# Altium schematic sheet CN032-1 Industry EtherCAT Servo Controller_LED&KEY.SchDoc
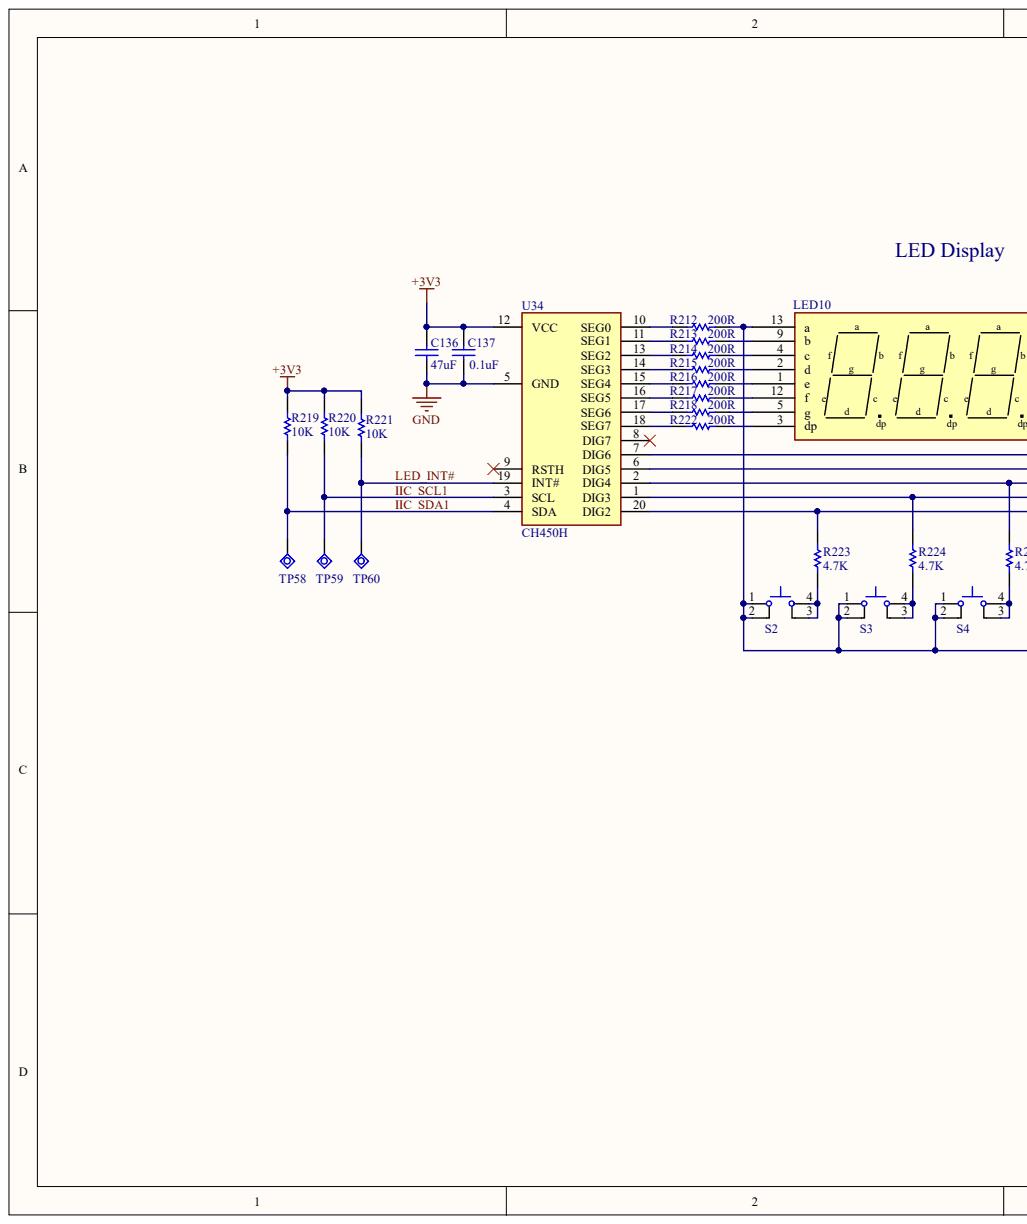
[diagram p0: CN032-1 Industry EtherCAT Servo Controller_LED&KEY.SchDoc, left]
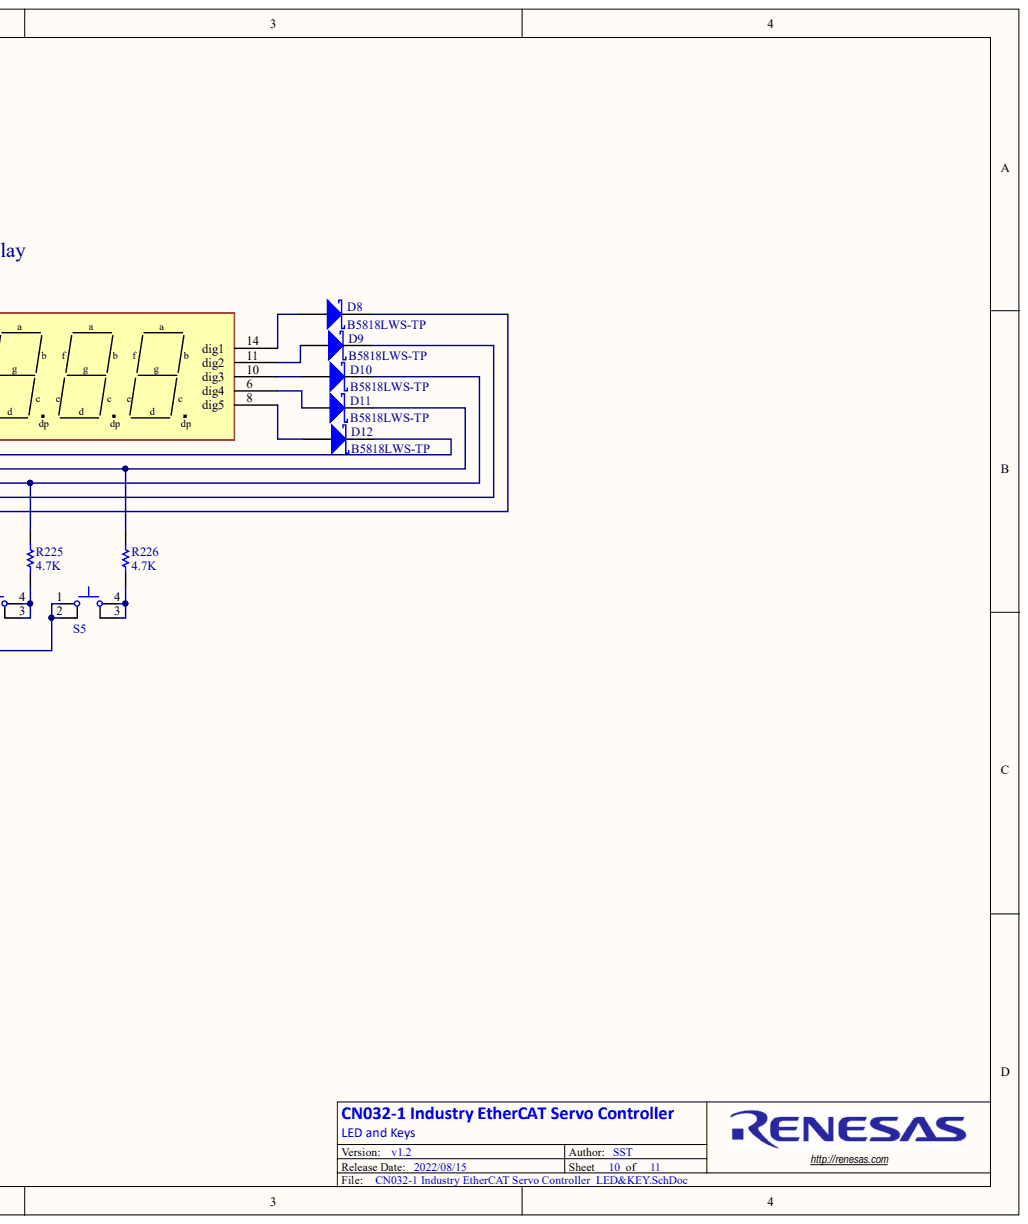
[diagram p1: CN032-1 Industry EtherCAT Servo Controller_LED&KEY.SchDoc, right]

## Parts
C136  47uF  [CAPC0805-01]
C137  0.1uF  [CAPC0603-01]
D8  B5818LWS-TP  [SC76]
D9  B5818LWS-TP  [SC76]
D10  B5818LWS-TP  [SC76]
D11  B5818LWS-TP  [SC76]
D12  B5818LWS-TP  [SC76]
LED10  LED Blue  [LED-5BIT]  (value not drawn)
R212  200R  [RESC0603-01]
R213  200R  [RESC0603-01]
R214  200R  [RESC0603-01]
R215  200R  [RESC0603-01]
R216  200R  [RESC0603-01]
R217  200R  [RESC0603-01]
R218  200R  [RESC0603-01]
R219  10K  [RESC0402-01]
R220  10K  [RESC0402-01]
R221  10K  [RESC0402-01]
R222  200R  [RESC0603-01]
R223  4.7K  [RESC0402-01]
R224  4.7K  [RESC0402-01]
R225  4.7K  [RESC0402-01]
R226  4.7K  [RESC0402-01]
S2  Switch 4.5x4.5mm  [KEY A (4.5 X 4.5)]  (value not drawn)
S3  Switch 4.5x4.5mm  [KEY A (4.5 X 4.5)]  (value not drawn)
S4  Switch 4.5x4.5mm  [KEY A (4.5 X 4.5)]  (value not drawn)
S5  Switch 4.5x4.5mm  [KEY A (4.5 X 4.5)]  (value not drawn)
TP58  Test Point  [TEST POINT]  (value not drawn)
TP59  Test Point  [TEST POINT]  (value not drawn)
TP60  Test Point  [TEST POINT]  (value not drawn)
U34  CH450H  [CH450DS1]

## Nets
+3V3: C136.2 C137.2 R219.1 R220.1 R221.1 U34.12(VCC)
GND: C136.1 C137.1 U34.5(GND)
IIC_SCL1: R220.2 TP59.1 U34.3(SCL)
IIC_SDA1: R219.2 TP58.1 U34.4(SDA)
LED_INT#: R221.2 TP60.1 U34.19(INT#)
NetD8_1: D8.1 R223.1 U34.20(DIG2)
NetD8_2: D8.2 LED10.14(dig1)
NetD9_1: D9.1 R224.1 U34.1(DIG3)
NetD9_2: D9.2 LED10.11(dig2)
NetD10_1: D10.1 R225.1 U34.2(DIG4)
NetD10_2: D10.2 LED10.10(dig3)
NetD11_1: D11.1 R226.1 U34.6(DIG5)
NetD11_2: D11.2 LED10.6(dig4)
NetD12_1: D12.1 U34.7(DIG6)
NetD12_2: D12.2 LED10.8(dig5)
NetLED10_1: LED10.1(e) R216.2
NetLED10_2: LED10.2(d) R215.2
NetLED10_3: LED10.3(dp) R222.2
NetLED10_4: LED10.4(c) R214.2
NetLED10_5: LED10.5(g) R218.2
NetLED10_9: LED10.9(b) R213.2
NetLED10_12: LED10.12(f) R217.2
NetLED10_13: LED10.13(a) R212.2 S2.1 S2.2 S3.1 S3.2 S4.1 S4.2 S5.1 S5.2
NetR212_1: R212.1 U34.10(SEG0)
NetR213_1: R213.1 U34.11(SEG1)
NetR214_1: R214.1 U34.13(SEG2)
NetR215_1: R215.1 U34.14(SEG3)
NetR216_1: R216.1 U34.15(SEG4)
NetR217_1: R217.1 U34.16(SEG5)
NetR218_1: R218.1 U34.17(SEG6)
NetR222_1: R222.1 U34.18(SEG7)
NetR223_2: R223.2 S2.3 S2.4
NetR224_2: R224.2 S3.3 S3.4
NetR225_2: R225.2 S4.3 S4.4
NetR226_2: R226.2 S5.3 S5.4

Not connected: U34 8(DIG7) 9(RSTH)

## Library data of the parts
C136 = CAP-0805-47uF: Ceramic Chip Capacitor 0805 47uF 10V; Manufacturer: TDK; Mfg Part Number: C2012X5R1A476M125AC; Dialectric: X5R; Tolerance: 20%; Capacitance: 47uF; Component Kind: Standard; Package: 0805; Voltage: 10V; Library Name: Synergy.SchLib
C137 = CAP-0603-0.1uF: Ceramic Chip Capacitor 0603 0.1uF 100V; Manufacturer: YAGEO; Mfg Part Number: CC0603KRX7R0BB104; Dialectric: X7R; Tolerance: 10%; Capacitance: 0.1uF; Component Kind: Standard; Package: 0603; Voltage: 100V; Library Name: Synergy.SchLib
D8-D12 = DIO-B5818LWS-TP: Schottky Diode 30V 1A SC-76; Manufacturer: Micro Commercial Co; Component Kind: Standard; Current: 1A; Display Name: B5818LWS-TP; Mfg Part Number: B5818LWS-TP; Package: SC-76; Voltage: 30V; Library Name: Synergy.SchLib
LED10 = LED-5bit: DISPLAY 7-SEG 0.56" 5 DIGIT RED; Manufacturer: TaoBao; Mfg Part Number: 0.36英寸LED数码管5位共阳; Component Kind: Standard; Library Name: Synergy.SchLib
R212-R218 R222 = RES-0603-200R: Chip Resistor Thick Film 0603 200R 1% 1/10W; Manufacturer: YAGEO; Mfg Part Number: RC0603FR-07200RL; Component Kind: Standard; Package: 0603; Power: 1/10W; Resistance: 200R; Tolerance: 1%; Library Name: Synergy.SchLib
R219-R221 = RES-0402-10K: Chip Resistor Thick Film 0402 10K 1% 1/16W; Manufacturer: YAGEO; Mfg Part Number: RC0402FR-0710KL; Component Kind: Standard; Package: 0402; Power: 1/16W; Resistance: 10K; Tolerance: 1%; Library Name: Synergy.SchLib
R223-R226 = RES-0402-4.7K: Chip Resistor Thick Film 0402 4.7K 1% 1/16W; Manufacturer: YAGEO; Mfg Part Number: RC0402FR-074K7L; Component Kind: Standard; Package: 0402; Power: 1/16W; Rating: 1%; Value: 4.7K; Library Name: Synergy.SchLib
S2-S5 = SWT-4.5x4.5mm: Push Button Switch 4.5 x 4.5mm 3.8mm High; Manufacturer: C&K; Mfg Part Number: PTS647SM38SMTR2 LFS; Component Kind: Standard; Library Name: Synergy.SchLib
TP58-TP60 = CON-Test Point: Component Kind: Standard; Description: Test Point; Library Name: Synergy.SchLib
U34 = ICN-CH450H: LED Driver; Manufacturer: WCH(南京沁恒); Component Kind: Standard; Display Name: CH450H; Mfg Part Number: CH450H; Library Name: Synergy.SchLib
